# Altium schematic sheet PORT.SchDoc(PORT)
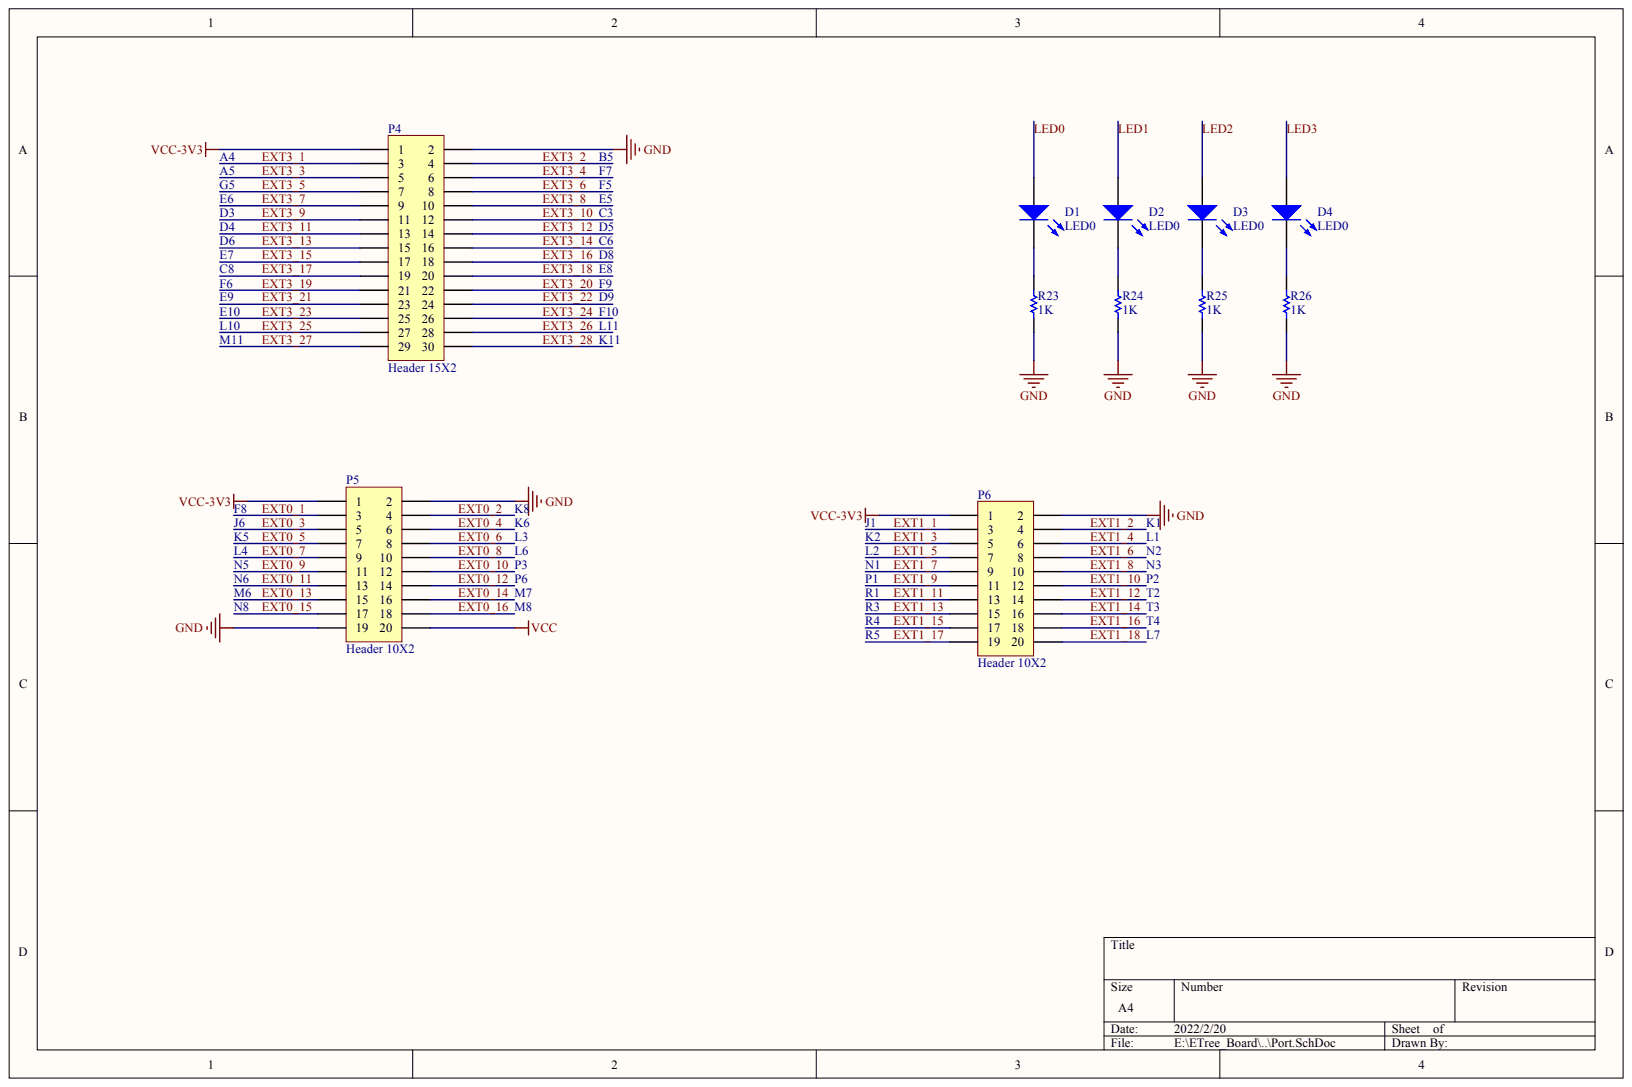
[diagram p0: PORT.SchDoc]

## Parts
D1  LED0
D2  LED0
D3  LED0
D4  LED0
P4  Header 15X2
P5  Header 10X2
P6  Header 10X2
R23  value 1K  (hidden comment: Res1)
R24  value 1K  (hidden comment: Res1)
R25  value 1K  (hidden comment: Res1)
R26  value 1K  (hidden comment: Res1)

## Nets
GND: P4.2 P5.2 P5.19 P6.2 R23.1 R24.1 R25.1 R26.1
VCC: P5.20
VCC-3V3: P4.1 P5.1 P6.1
EXT0_1: P5.3
EXT0_2: P5.4
EXT0_3: P5.5
EXT0_4: P5.6
EXT0_5: P5.7
EXT0_6: P5.8
EXT0_7: P5.9
EXT0_8: P5.10
EXT0_9: P5.11
EXT0_10: P5.12
EXT0_11: P5.13
EXT0_12: P5.14
EXT0_13: P5.15
EXT0_14: P5.16
EXT0_15: P5.17
EXT0_16: P5.18
EXT1_1: P6.3
EXT1_2: P6.4
EXT1_3: P6.5
EXT1_4: P6.6
EXT1_5: P6.7
EXT1_6: P6.8
EXT1_7: P6.9
EXT1_8: P6.10
EXT1_9: P6.11
EXT1_10: P6.12
EXT1_11: P6.13
EXT1_12: P6.14
EXT1_13: P6.15
EXT1_14: P6.16
EXT1_15: P6.17
EXT1_16: P6.18
EXT1_17: P6.19
EXT1_18: P6.20
EXT3_1: P4.3
EXT3_2: P4.4
EXT3_3: P4.5
EXT3_4: P4.6
EXT3_5: P4.7
EXT3_6: P4.8
EXT3_7: P4.9
EXT3_8: P4.10
EXT3_9: P4.11
EXT3_10: P4.12
EXT3_11: P4.13
EXT3_12: P4.14
EXT3_13: P4.15
EXT3_14: P4.16
EXT3_15: P4.17
EXT3_16: P4.18
EXT3_17: P4.19
EXT3_18: P4.20
EXT3_19: P4.21
EXT3_20: P4.22
EXT3_21: P4.23
EXT3_22: P4.24
EXT3_23: P4.25
EXT3_24: P4.26
EXT3_25: P4.27
EXT3_26: P4.28
EXT3_27: P4.29
EXT3_28: P4.30
LED0: D1.1
LED1: D2.1
LED2: D3.1
LED3: D4.1
NetD1_2: D1.2 R23.2
NetD2_2: D2.2 R24.2
NetD3_2: D3.2 R25.2
NetD4_2: D4.2 R26.2

## Library data of the parts
D1-D4 P4-P6 R23-R26 = (no symbol name): Publisher: Altium Limited
  D1-D4: Published: 8-Jun-2000; LatestRevisionDate: 08-Jul-2005; LatestRevisionNote: Mech Layer 1 removed.; PackageReference: LED-0; PackageDescription: LED; 2 Leads
  P4-P6: LatestRevisionDate: 17-Jul-2002; LatestRevisionNote: Re-released for DXP Platform.
  R23-R26: Published: 8-Jun-2000; LatestRevisionDate: 17-Jul-2002; LatestRevisionNote: Re-released for DXP Platform.; PackageReference: AXIAL-0.3; PackageDescription: Axial Device, Thru-Hole; 2 Leads; 0.3 in Pin Spacing
